# Altium schematic sheet OBD2-ESP32-S3.SchDoc(OBD2-ESP32-S3)
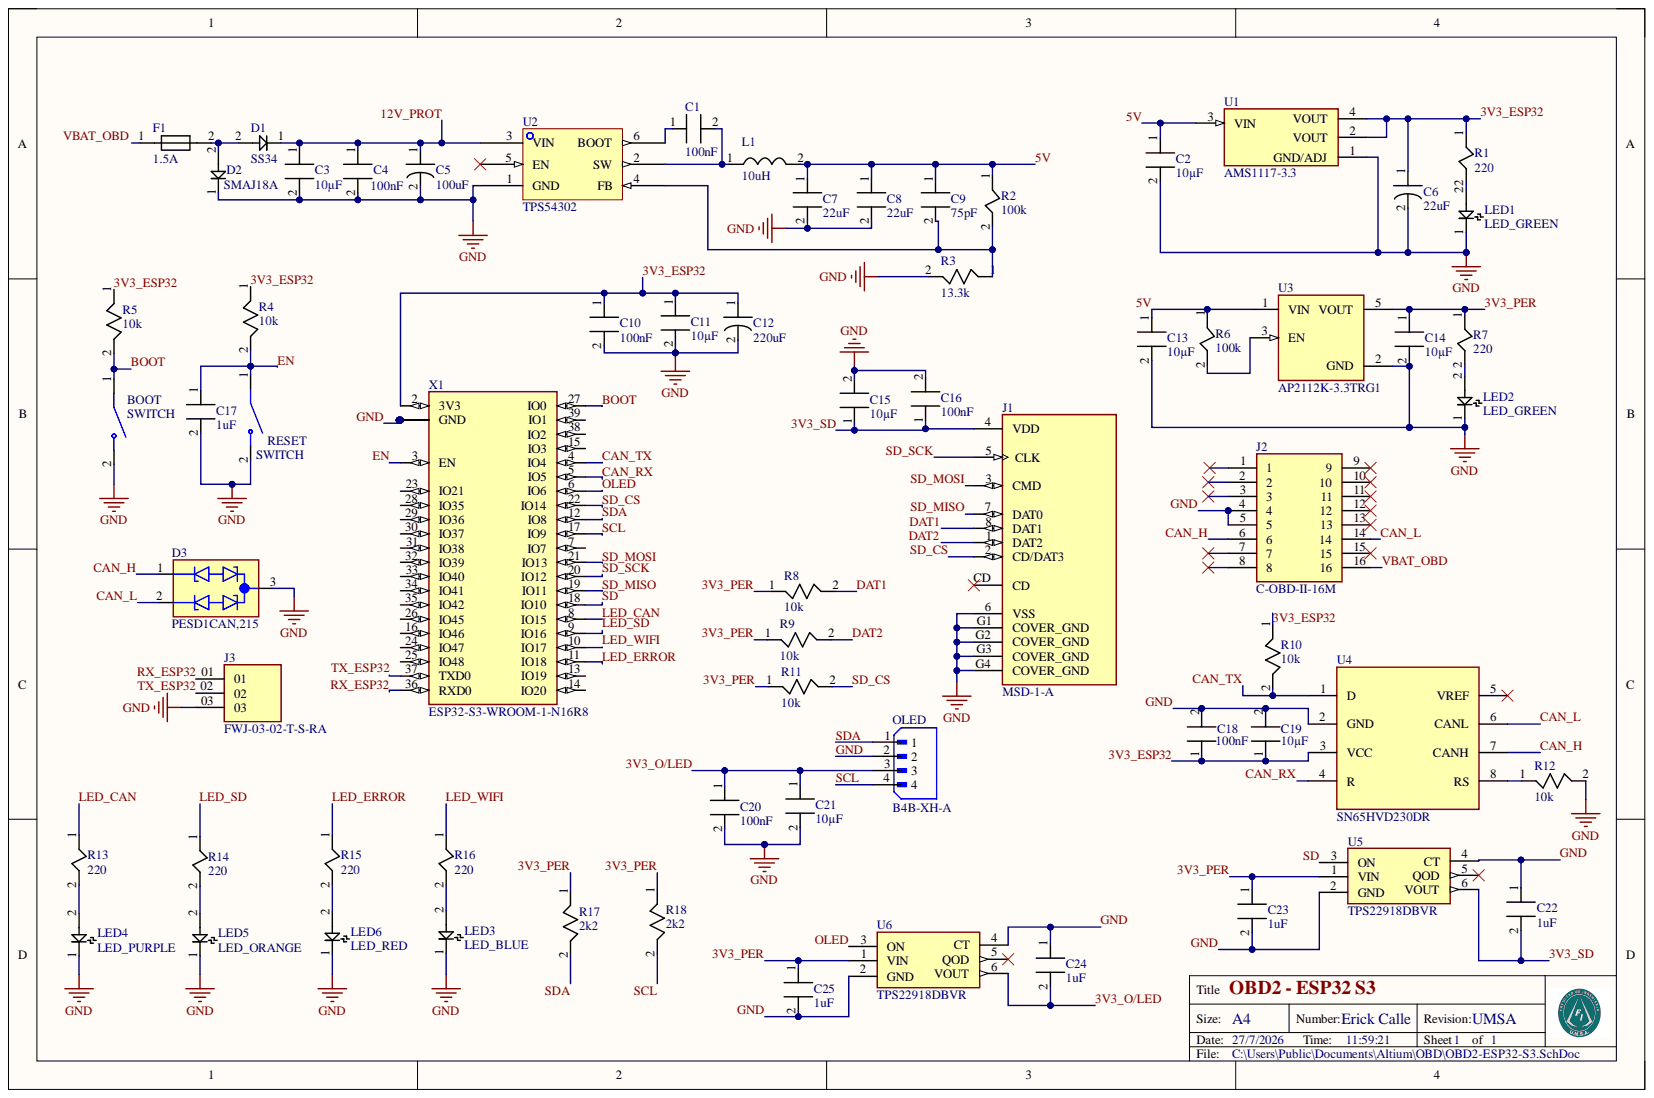
[diagram p0: OBD2-ESP32-S3.SchDoc]

## Parts
BOOT  SWITCH  [SW_TS-1088-AR02016]
C1  100nF  [CAPC1608X80N]
C2  10µF  [CAPC2012X125N]
C3  10µF  [CAPC3325X220N]
C4  100nF  [CAPC2012X85N]
C5  100uF  [CAPAE660X770M]
C6  22uF  [CAPMP3228X210N]
C7  22uF  [CAPC3225X280N]
C8  22uF  [CAPC3225X280N]
C9  75pF  [CAPC1608X80NS]
C10  100nF  [CAPC1608X80N]
C11  10µF  [CAPC2012X125N]
C12  220uF  [CAPMP3228X210NS]
C13  10µF  [CAPC2012X125N]
C14  10µF  [CAPC2012X125N]
C15  10µF  [CAPC2012X125N]
C16  100nF  [CAPC1608X80N]
C17  1uF  [CAPC1005X50N]
C18  100nF  [CAPC1608X80N]
C19  10µF  [CAPC2012X125N]
C20  100nF  [CAPC1608X80N]
C21  10µF  [CAPC2012X125N]
C22  1uF  [CAPC1005X50N]
C23  1uF  [CAPC1005X50N]
C24  1uF  [CAPC1005X50N]
C25  1uF  [CAPC1005X50N]
D1  SS34  [DIOM4226X242N]
D2  SMAJ18A  [DIOM4428X244N]
D3  PESD1CAN,215  [DIO_PESD1CAN,215]
F1  1.5A  [CAPC4632X150N]
J1  MSD-1-A  [CUI_MSD-1-A]
J2  C-OBD-II-16M  [COMTECH_C-OBD-II-16M]
J3  FWJ-03-02-T-S-RA  [SAMTEC_FWJ-03-02-T-S-RA]
L1  10uH  [INDP7066X220N]
LED1  LED_GREEN  [DIOC1608X28N]
LED2  LED_GREEN  [DIOC1608X28N]
LED3  LED_BLUE  [DIOC1608X28N]
LED4  LED_PURPLE  [DIOC1608X28N]
LED5  LED_ORANGE  [DIOC1608X28N]
LED6  LED_RED  [DIOC1608X28N]
OLED  B4B-XH-A  [JST_B4B-XH-A]
R1  220  [RESC1005X30N]
R2  100k  [RESC1608X80N]
R3  13.3k  [RESC1608X80N]
R4  10k  [RESC1608X80N]
R5  10k  [RESC1608X80N]
R6  100k  [RESC1608X80N]
R7  220  [RESC1005X30N]
R8  10k  [RESC1608X80N]
R9  10k  [RESC1608X80N]
R10  10k  [RESC1608X80N]
R11  10k  [RESC1608X80N]
R12  10k  [RESC1608X80N]
R13  220  [RESC1005X30N]
R14  220  [RESC1005X30N]
R15  220  [RESC1005X30N]
R16  220  [RESC1005X30N]
R17  2k2  [RESC1608X80N]
R18  2k2  [RESC1608X80N]
RESET  SWITCH  [SW_TS-1088-AR02016]
U1  AMS1117-3.3  [SOT230P700X180-4N]
U2  TPS54302  [SOT95P280X110-6N]
U3  AP2112K-3.3TRG1  [SOT95P285X140-5N]
U4  SN65HVD230DR  [SOIC127P599X175-8N]
U5  TPS22918DBVR  [SOT95P280X145-6N]
U6  TPS22918DBVR  [SOT95P280X145-6N]
X1  ESP32-S3-WROOM-1-N16R8  [ESP32S3WROOM1N8R2]

## Nets
3V3_ESP32: C6.1 C10.1 C11.1 C12.1 C18.1 C19.1 R1.1 R4.1 R5.1 R10.1 U1.2(VOUT) U1.4(VOUT) U4.3(VCC) X1.2(3V3)
3V3_O/LED: C20.1 C21.1 C24.2 OLED.3 U6.6(VOUT)
3V3_PER: C14.1 C23.1 C25.1 R7.1 R8.1 R9.1 R11.1 R17.1 R18.1 U3.5(VOUT) U5.1(VIN) U6.1(VIN)
3V3_SD: C15.1 C16.1 C22.2 J1.4(VDD) U5.6(VOUT)
5V: C2.1 C7.1 C8.1 C9.1 C13.1 L1.2 R2.1 R6.1 U1.3(VIN) U3.1(VIN)
12V_PROT: C3.1 C4.1 C5.1 D1.1 U2.3(VIN)
BOOT: BOOT.1 R5.2 X1.27(IO0)
CAN_H: D3.1 J2.6 U4.7(CANH)
CAN_L: D3.2 J2.14 U4.6(CANL)
CAN_RX: U4.4(R) X1.5(IO5)
CAN_TX: R10.2 U4.1(D) X1.4(IO4)
DAT1: J1.8(DAT1) R8.2
DAT2: J1.1(DAT2) R9.2
EN: C17.1 R4.2 RESET.1 X1.3(EN)
GND: BOOT.2 C2.2 C3.2 C4.2 C5.2 C6.2 C7.2 C8.2 C10.2 C11.2 C12.2 C13.2 C14.2 C15.2 C16.2 C17.2 C18.2 C19.2 C20.2 C21.2 C22.1 C23.2 C24.1 C25.2 D2.1 D3.3 J1.6(VSS) J1.G1(COVER_GND) J1.G2(COVER_GND) J1.G3(COVER_GND) J1.G4(COVER_GND) J2.4 J2.5 J3.03 LED1.1 LED2.1 LED3.1 LED4.1 LED5.1 LED6.1 OLED.2 R3.2 R12.2 RESET.2 U1.1(GND/ADJ) U2.1(GND) U3.2(GND) U4.2(GND) U5.2(GND) U5.4(CT) U6.2(GND) U6.4(CT) X1.1(GND) X1.40 X1.45 X1.46
LED_CAN: R13.1 X1.8(IO15)
LED_ERROR: R15.1 X1.11(IO18)
LED_SD: R14.1 X1.9(IO16)
LED_WIFI: R16.1 X1.10(IO17)
OLED: U6.3(ON) X1.6(IO6)
RX_ESP32: J3.01 X1.36(RXD0)
SCL: OLED.4 R18.2 X1.17(IO9)
SD: U5.3(ON) X1.18(IO10)
SD_CS: J1.2(CD/DAT3) R11.2 X1.22(IO14)
SD_MISO: J1.7(DAT0) X1.19(IO11)
SD_MOSI: J1.3(CMD) X1.21(IO13)
SD_SCK: J1.5(CLK) X1.20(IO12)
SDA: OLED.1 R17.2 X1.12(IO8)
TX_ESP32: J3.02 X1.37(TXD0)
VBAT_OBD: F1.1 J2.16
NetC1_1: C1.1 U2.6(BOOT)
NetC1_2: C1.2 L1.1 U2.2(SW)
NetC9_2: C9.2 R2.2 R3.1 U2.4(FB)
NetD1_2: D1.2 D2.2 F1.2
NetLED1_2: LED1.2 R1.2
NetLED2_2: LED2.2 R7.2
NetLED3_2: LED3.2 R16.2
NetLED4_2: LED4.2 R13.2
NetLED5_2: LED5.2 R14.2
NetLED6_2: LED6.2 R15.2
NetR6_2: R6.2 U3.3(EN)
NetR12_1: R12.1 U4.8(RS)
NetX1_41: X1.41 X1.42 X1.43 X1.44 X1.49

Not connected: J1 CD; J2 1 2 3 7 8 9 10 11 12 13 15; U2 5(EN); U4 5(VREF); U5 5(QOD); U6 5(QOD); X1 7(IO7) 13(IO19) 14(IO20) 15(IO3) 16(IO46) 23(IO21) 24(IO47) 25(IO48) 26(IO45) 28(IO35) 29(IO36) 30(IO37) 31(IO38) 32(IO39) 33(IO40) 34(IO41) 35(IO42) 38(IO2) 39(IO1)

## Library data of the parts
BOOT RESET = SWICH: 50mA 4mm 100MÎ© 100,000 Times 12V 160gf 3mm 2mm Round Button Vertical welding SPST SMD Tactile Switches ROHS; Component Kind: Standard; LCSC: C720477; Library Name: OBD2.SchLib
C1 C10 C16 C18 C20 = CAP_100nF_16V_X7R_0603: Component Kind: Standard; LCSC: C20539422; Library Name: OBD2.SchLib
C2 C11 C13-C15 C19 C21 = CAP_10uF_16V_X7R_0805: Component Kind: Standard; LCSC: C2182156; Library Name: OBD2.SchLib
C3 = CAP_10uF_50V_X7R_1210: Component Kind: Standard; LCSC: C386166; Library Name: OBD2.SchLib
C4 = CAP_100nF_50V_X7R_0805: Component Kind: Standard; LCSC: C282732; Library Name: OBD2.SchLib
C5 = CAP_ELEC_100uF_50V: Component Kind: Standard; LCSC: C3151829; Library Name: OBD2.SchLib
C6 = CAP_22uF_TAN: Component Kind: Standard; LCSC: C1953638; Library Name: OBD2.SchLib
C7 C8 = CAP_22uF_16V_X7R_1210: Component Kind: Standard; LCSC: C55530; Library Name: OBD2.SchLib
C9 = CAP_75pF_50V_NP0_0603: Component Kind: Standard; LCSC: C282074; Library Name: OBD2.SchLib
C12 = CAP_220uF_TAN: Component Kind: Standard; LCSC: C2887903; Library Name: OBD2.SchLib
C17 C22-C25 = CAP_1uF_10V_0402: Component Kind: Standard; LCSC: C5137473; Library Name: OBD2.SchLib
D3 = PESD1CAN,215: 70V Clamp 3A (8/20Âµs) Ipp Tvs Diode Surface Mount TO-236AB; Component Kind: Standard; LCSC: C15771; Library Name: OBD2.SchLib
F1 = FUSE_1.5A: Component Kind: Standard; LCSC: C18198333; Library Name: OBD2.SchLib
J1 = MSD-1-A: Micro SD Card Connector, 9 Positions, Connector and Ejector, Push In, Auto Eject Out, Surface Mount, 1.8 mm Height Above Board, Gold Flash; Component Kind: Standard; LCSC: C393941; Library Name: OBD2.SchLib
J2 = C-OBD-II-16M: OBD II 16pin 90 Degree Angle Male Plug Connector; MF: Comtech Electronic Co., LTD; Check_prices: https://www.snapeda.com/parts/C-OBD-II-16M/Comtech+Electronic+Co.%252C+LTD/view-part/?ref=eda; Component Kind: Standard; LCSC: C9900147921; Library Name: OBD2.SchLib; MP: C-OBD-II-16M; Package: None; SnapEDA_Link: https://www.snapeda.com/parts/C-OBD-II-16M/Comtech+Electronic+Co.%252C+LTD/view-part/?ref=snap
J3 = FWJ-03-02-T-S-RA: Connector Header Through Hole, Right Angle 3 position 0.156 (3.96mm); Component Kind: Standard; LCSC: C2937625; Library Name: OBD2.SchLib
L1 = IND_10uH: Component Kind: Standard; LCSC: C524608; Library Name: OBD2.SchLib
LED4 = LED_PURPE: Component Kind: Standard; LCSC: C7496831; Library Name: OBD2.SchLib
OLED = B4B-XH-A: Connector Header Through Hole 4 position 0.098 (2.50mm); Component Kind: Standard; LCSC: C144395; Library Name: OBD2.SchLib
R1 R7 R13-R16 = RES_220_0402: Component Kind: Standard; LCSC: C140180; Library Name: OBD2.SchLib
R2 R6 = RES_100k_0603: Component Kind: Standard; LCSC: C2906980; Library Name: OBD2.SchLib
R3 = RES_13.3k_0603: Component Kind: Standard; LCSC: C2933140; Library Name: OBD2.SchLib
R4 R5 R8-R12 = RES_10k_0603: Component Kind: Standard; LCSC: C2906982; Library Name: OBD2.SchLib
R17 R18 = RES_2k2_0603: Component Kind: Standard; LCSC: C2907005; Library Name: OBD2.SchLib
U1 = AMS1117-3.3: Sot223/Pkg 1-Amp 3.3-Volt Low Drop Out Voltage Regulatator; MF: Advanced Monolithic Systems; Check_prices: https://www.snapeda.com/parts/AMS1117-3.3/Advanced+Monolithic+Systems/view-part/?ref=eda; Component Kind: Standard; LCSC: C2992570; Library Name: OBD2.SchLib; MP: AMS1117-3.3; Package: SOT-223 Seeed Technology; SnapEDA_Link: https://www.snapeda.com/parts/AMS1117-3.3/Advanced+Monolithic+Systems/view-part/?ref=snap
U3 = AP2112K-3.3TRG1: Linear Voltage Regulator IC Positive Fixed 1 Output 600mA SOT-25; MF: Diodes Inc.; Availability: In Stock; Check_prices: https://www.snapeda.com/parts/AP2112K-3.3TRG1/Diodes+Inc./view-part/?ref=eda; Component Kind: Standard; LCSC: C51118; Library Name: OBD2.SchLib; MP: AP2112K-3.3TRG1; Package: SOT-753 Diodes Inc.; Price: None; Purchase-URL: https://pricing.snapeda.com/search/part/AP2112K-3.3TRG1/?ref=eda; SnapEDA_Link: https://www.snapeda.com/parts/AP2112K-3.3TRG1/Diodes+Inc./view-part/?ref=snap
U4 = SN65HVD230DR: 3.3 V CAN Transceiver with Standby Mode; MF: Texas Instruments; Check_prices: https://www.snapeda.com/parts/SN65HVD230DR/Texas+Instruments/view-part/?ref=eda; Component Kind: Standard; LCSC: C12084; Library Name: OBD2.SchLib; MP: SN65HVD230DR; Package: SOIC-8 Texas Instruments; SnapEDA_Link: https://www.snapeda.com/parts/SN65HVD230DR/Texas+Instruments/view-part/?ref=snap
U5 U6 = TPS22918DBVR: 5.5-V, 2-A, 52-mÎ© load switch with adj. rise time and adj. output discharge; Component Kind: Standard; LCSC: C131941; Library Name: OBD2.SchLib
X1 = ESP32-S3-WROOM-1-N16R8: Bluetooth, WiFi 802.11b/g/n, Bluetooth v5.0 Transceiver Module 2.4GHz PCB Trace Surface Mount; Component Kind: Standard; LCSC: C2913202; Library Name: OBD2.SchLib
D1 = SS34: Component Kind: Standard; LCSC: C52023881; Library Name: OBD2.SchLib
D2 = SMAJ18A: Component Kind: Standard; LCSC: C50087694; Library Name: OBD2.SchLib
LED1 LED2 = LED_GREEN: Component Kind: Standard; LCSC: C7371905; Library Name: OBD2.SchLib
LED3 = LED_BLUE: Component Kind: Standard; LCSC: C965807; Library Name: OBD2.SchLib
LED5 = LED_ORANGE: Component Kind: Standard; LCSC: C965800; Library Name: OBD2.SchLib
LED6 = LED_RED: Component Kind: Standard; LCSC: C965799; Library Name: OBD2.SchLib
U2 = TPS54302: Component Kind: Standard; LCSC: C311983; Library Name: OBD2.SchLib
